# Altium schematic sheet peripherals.SchDoc
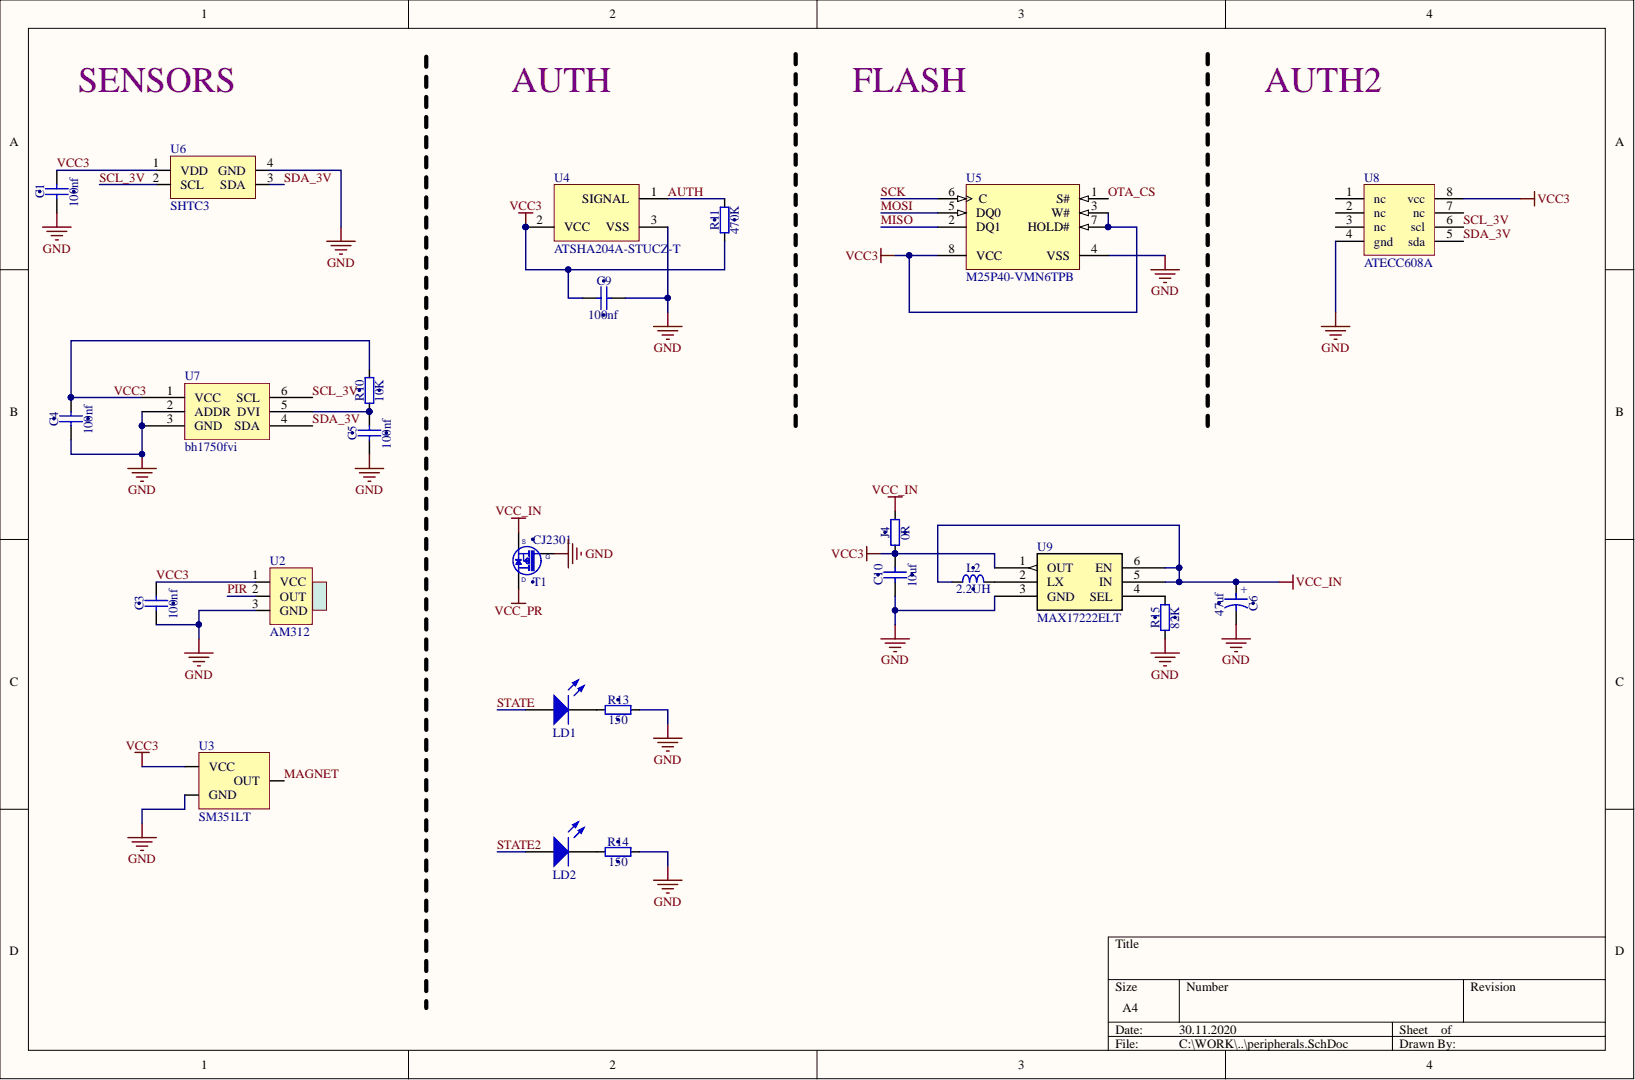
[diagram p0: peripherals.SchDoc]

## Parts
C1  100nf  [CAP_0603]
C3  100nf  [CAP_0603]
C4  100nf  [CAP_0603]
C5  100nf  [CAP_0603]
C6  47uf  [CAP_3216]
C9  100nf  [CAP_0603]
C10  10uf  [CAP_0805]
J4  0R  [RES_0603]
L2  2.2UH  [INDC2520X10N_1008]
LD1  0603 red  [0603_A]  (value not drawn)
LD2  0603 green  [0603_A]  (value not drawn)
R10  10K  [RES_0603]
R11  470K  [RES_0603]
R13  150  [RES_0603]
R14  150  [RES_0603]
R15  82K  [RES_0603]
T1  CJ2301  [SOT95P240X110-3N]
U2  AM312  [AM312]
U3  SM351LT  [SOT95P240X120-3N]
U4  ATSHA204A-STUCZ-T  [SOT95P240X110-3N]
U5  M25P40-VMN6TPB  [SOIC127P600X175-8N]
U6  SHTC3  [shtc3]
U7  bh1750fvi  [BH1750]
U8  ATECC608A  [UDFN_2x3mm_Q4B]
U9  MAX17222ELT  [MAX17222_UDFN6]

## Nets
GND: C1.1 C3.1 C4.1 C5.1 C6.2 C9.2 C10.1 R13.2 R14.2 R15.1 T1.1 U2.3 U3.3 U4.3 U5.4(VSS) U6.4(GND) U7.2(ADDR) U7.3(GND) U8.4(gnd) U9.3(GND)
VCC_IN: C6.1 J4.2 L2.1 T1.2 U9.5(IN) U9.6(EN)
VCC_PR: T1.3
AUTH: R11.2 U4.1
MAGNET: U3.2
MISO: U5.2(DQ1)
MOSI: U5.5(DQ0)
OTA_CS: U5.1(S#)
PIR: U2.2
SCK: U5.6(C)
SCL_3V: U6.2(SCL) U7.6(SCL) U8.6(scl)
SDA_3V: U6.3(SDA) U7.4(SDA) U8.5(sda)
STATE: LD1.2
STATE2: LD2.2
VCC3: C1.2 C3.2 C4.2 C9.1 C10.2 J4.1 R10.2 R11.1 U2.1 U3.1 U4.2 U5.3(W#) U5.7(HOLD#) U5.8(VCC) U6.1(VDD) U7.1(VCC) U8.8(vcc) U9.1(OUT)
NetC5_2: C5.2 R10.1 U7.5(DVI)
NetL2_2: L2.2 U9.2(LX)
NetLD1_1: LD1.1 R13.1
NetLD2_1: LD2.1 R14.1
NetR15_2: R15.2 U9.4(SEL)

Not connected: U8 1(nc) 2(nc) 3(nc) 7(nc)

## Library data of the parts
C1 C3-C5 C9 = C0603_100NF: Non-polarised capacitor; Database Table Name: CAP; GoodsBriefName: RC_C_0603_100NF_1PCS; GoodsID: 47802; Код: 2; Case: 0603; Component Kind: Standard; Part Number: C0603_100NF; Pin Count: 2; Value: 100nf; Library Name: MIPS_COMPONENTS_LIB.DbLib
C6 = CT_47UF_6.3V_3216: Polarised capacitor; Database Table Name: CAP; GoodsBriefName: RC_C_1206_47UF_TANTALUM; GoodsID: 52840; Код: 11; Case: 3216; Component Kind: Standard; Part Number: CT_47UF_6.3V_3216; Pin Count: 2; Value: 47uf; Поле1: ?; Library Name: MIPS_COMPONENTS_LIB.DbLib
C10 = C0805_10UF_16V_TDK: Non-polarised capacitor; Database Table Name: CAP; GoodsBriefName: RC_C_0805_10UF_16V; GoodsID: 60653; Код: 22; Case: 0805; Component Kind: Standard; Part Number: C0805_10UF_16V_TDK; Pin Count: 2; Value: 10uf; Library Name: MIPS_COMPONENTS_LIB.DbLib
J4 = R0603_0R_1%: Resistor; Database Table Name: res; GoodsBriefName: RC_R_0603_0OM_1PCS; GoodsID: 59839; Код: 7; Case: 0603; Component Kind: Standard; Part Number: R0603_0R_1%; Pin Count: 2; Value: 0R; Library Name: MIPS_COMPONENTS_LIB.DbLib
L2 = IND_2.2UH_2520: Inductor; Database Table Name: IND; Код: 9; Case: 2520; Component Kind: Standard; Part Number: IND_2.2UH_2520; Pin Count: 2; Value: 2.2UH; Library Name: MIPS_COMPONENTS_LIB.DbLib
LD1 = LED_0603_RED: SMD mono-color Chip LED, Red; Database Table Name: MISC; GoodsBriefName: RC_LED_SMD_0603_RED_LSR-0603; GoodsID: 51415; Код: 9; Case: 0603; Component Kind: Standard; Part Number: LED_0603_RED; Pin Count: 2; Value: 0603_RED; Library Name: MIPS_COMPONENTS_LIB.DbLib
LD2 = LED_0603_GREEN: SMD mono-color Chip LED, Red; Database Table Name: MISC; GoodsBriefName: RC_LED_SMD_0603_YELLOW-GREEN; GoodsID: 59403; Код: 10; Case: 0603; Component Kind: Standard; Part Number: LED_0603_GREEN; Pin Count: 2; Value: 0603_GREEN; Library Name: MIPS_COMPONENTS_LIB.DbLib
R10 = R0603_10K_1%: Resistor; Database Table Name: res; GoodsBriefName: RC_R_0603_10KOM_1PERCENT_1PCS; GoodsID: 59406; Код: 10; Case: 0603; Component Kind: Standard; Part Number: R0603_10K_1%; Pin Count: 2; Value: 10K; Library Name: MIPS_COMPONENTS_LIB.DbLib
R11 = R0603_470K_1%: Resistor; Database Table Name: res; GoodsBriefName: RC_R_0603_470KOM_1PERCENT; GoodsID: 60645; Код: 25; Case: 0603; Component Kind: Standard; Part Number: R0603_470K_1%; Pin Count: 2; Value: 470K; Library Name: MIPS_COMPONENTS_LIB.DbLib
R13 R14 = R0603_150_1%: Resistor; Database Table Name: res; GoodsBriefName: RC_R_0603_150OM_5PERCENT; GoodsID: 49755; Код: 19; Case: 0603; Component Kind: Standard; Part Number: R0603_150_1%; Pin Count: 2; Value: 150; Library Name: MIPS_COMPONENTS_LIB.DbLib
R15 = R0603_82K_1%: Resistor; Database Table Name: res; Код: 43; Case: 0603; Component Kind: Standard; Part Number: R0603_82K_1%; Pin Count: 2; Value: 82K; Library Name: MIPS_COMPONENTS_LIB.DbLib
T1 = TR_CJ2301: P-CHANNEL MOSFET WITH DIODE; Database Table Name: TR; GoodsBriefName: RC_TR_CJ2301_SOT23-3; GoodsID: 52844; Код: 2; Case: SOT23; Component Kind: Standard; Part Number: TR_CJ2301; Pin Count: 3; Value: CJ2301; Library Name: MIPS_COMPONENTS_LIB.DbLib
U3 = IC_SM351LT: Database Table Name: IC; Код: 26; Case: SOT23-3; Component Kind: Standard; Part Number: IC_SM351LT; Pin Count: 3; Value: SM351LT; Library Name: MIPS_COMPONENTS_LIB.DbLib
U4 = IC_ATSHA204A: CryptoAuthentication™ Host Security Chip, Industrial (-40 to 85 degC), 3-Pin SOT, Tape and Reel, Pb-Free; Database Table Name: IC; GoodsBriefName: RC_IC_ATSHA204A-STUCZ-T_SOT23; GoodsID: 52067; Код: 20; Case: SOT23-3; Component Kind: Standard; Part Number: IC_ATSHA204A; Pin Count: 3; Value: ATSHA204A-STUCZ-T; Library Name: MIPS_COMPONENTS_LIB.DbLib
U5 = IC_M25P40-VMN-8: 4 Mb (x1) Serial NOR Flash Memory, 75 MHz, 2.3 to 3.6 V, 8-pin SO8 Narrow (MN), Tape & Reel; Manufacturer: Micron; Part Family: M25PÂ; Database Table Name: IC; Density: 4MbÂ; GoodsBriefName: RC_IC_M25P40-VMN6TPB; GoodsID: 52086; Speed: 75 MHzÂ; Voltage: 2.3V-3.6VÂ; Код: 21; Applications: EmbeddedÂ; Case: SOP8; Component Kind: Standard; ComponentLink1Description: Manufacturer URL; ComponentLink1URL: http://www.micron.com/; ComponentLink2Description: Datasheet; ComponentLink2URL: http://www.micron.com/~/media/Documents/Products/Data%20Sheet/NOR%20Flash/Serial%20NOR/M25P/M25P40.pdf; ComponentLink3Description: Package Specification; ComponentLink3URL: http://www.micron.com/~/media/Documents/Products/Data%20Sheet/NOR%20Flash/Serial%20NOR/M25P/M25P05A.pdf; DatasheetVersion: Rev. Y; Mounting Technology: Surface Mount; Package: SO8 NarrowÂ; PackageDescription: 8-Pin SO Narrow, 3.9 X 4.9 mm, Pitch 1.27 mm; PackageReference: MICT-MN-8; PackageVersion: Rev 11; Part Number: IC_M25P40-VMN-8; PartNumber: M25P40-VMN6TPB; Part Status: ProductionÂ; Pin Count: 8; RoHS: YesÂ; Type: Data StorageÂ; Value: M25P40-VMN-8; Width: x1Â; Library Name: MIPS_COMPONENTS_LIB.DbLib
U6 = SENSOR_SHTC3: Database Table Name: SENSORS; Код: 5; Component Kind: Standard; Part Number: SENSOR_SHTC3; Pin Count: 4; Value: SHTC3; Library Name: MIPS_COMPONENTS_LIB.DbLib
U7 = SENSOR_BH1750: Database Table Name: SENSORS; GoodsBriefName: ARDUINO_SENSOR_LIGHT_INTENSITY_IC_BH1750FVI_WSOF6; GoodsID: 53450; Код: 1; Component Kind: Standard; Part Number: SENSOR_BH1750; Pin Count: 6; Value: bh1750fvi; Library Name: MIPS_COMPONENTS_LIB.DbLib
U8 = IC_ATECC608A: Database Table Name: IC; Код: 29; Case: UDFN8; Component Kind: Standard; Part Number: IC_ATECC608A; Pin Count: 8; Value: ATECC608A; Library Name: MIPS_COMPONENTS_LIB.DbLib
U9 = IC_MAX17222: Database Table Name: IC; Код: 28; Case: UDFN6; Component Kind: Standard; Part Number: IC_MAX17222; Pin Count: 6; Value: MAX17222; Library Name: MIPS_COMPONENTS_LIB.DbLib
U2 = AM312: Component Kind: Standard; Library Name: rf69.SchLib
